# Altium schematic sheet 05 - BANK 14 DUAL ETHERNET, UART, GPIO.SchDoc
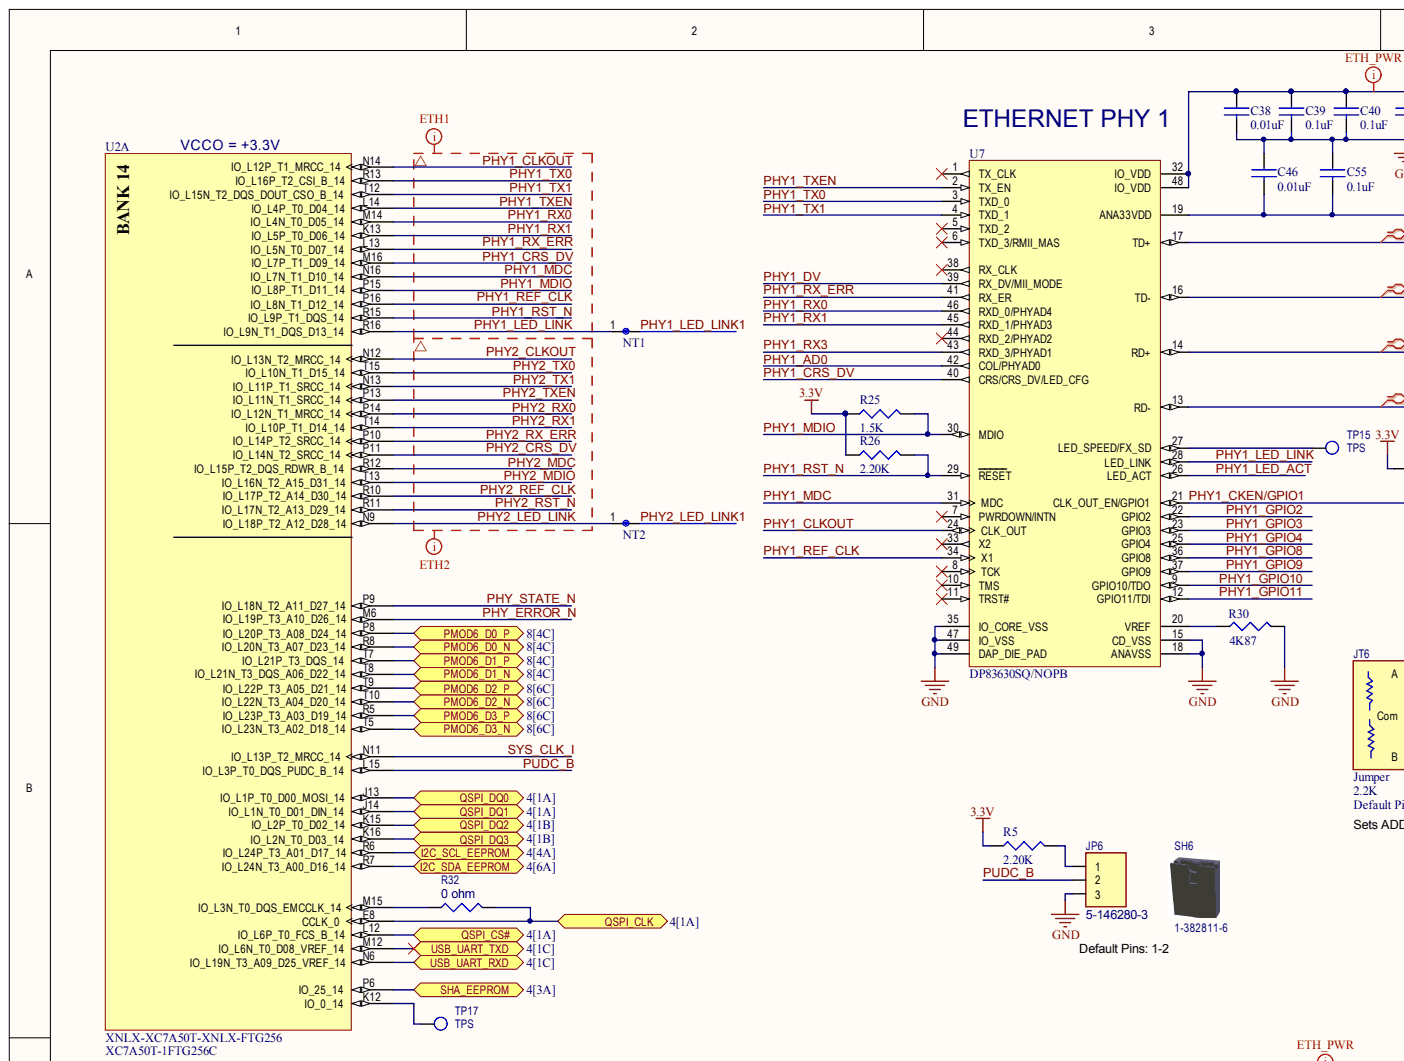
[diagram p0: 05 - BANK 14 DUAL ETHERNET, UART, GPIO.SchDoc, top left]
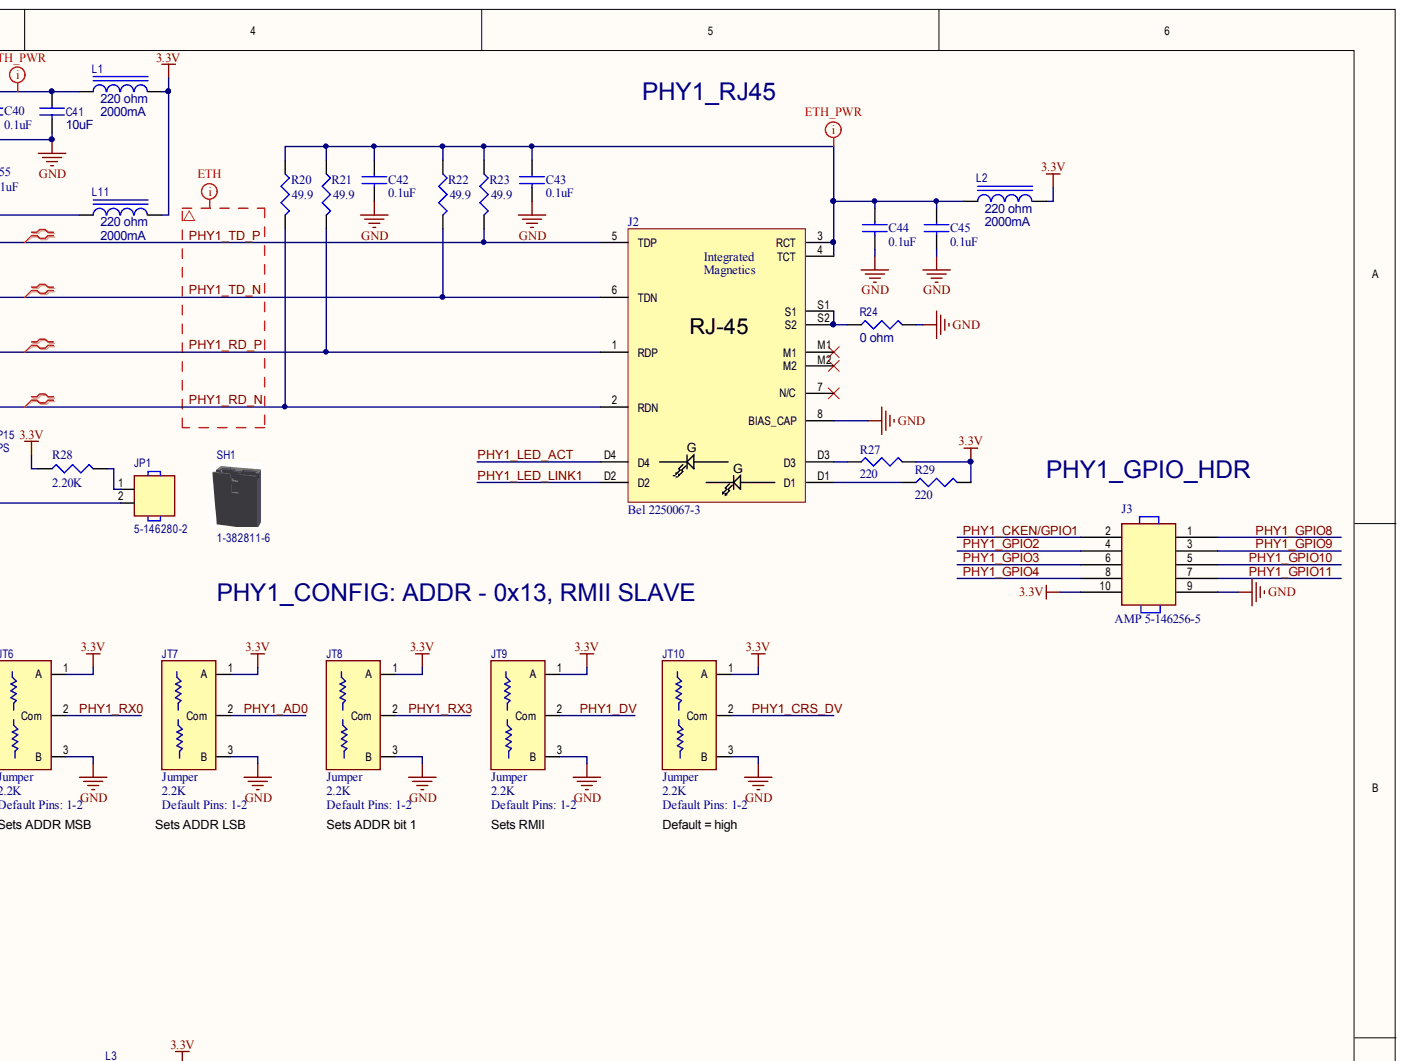
[diagram p1: 05 - BANK 14 DUAL ETHERNET, UART, GPIO.SchDoc, top right]
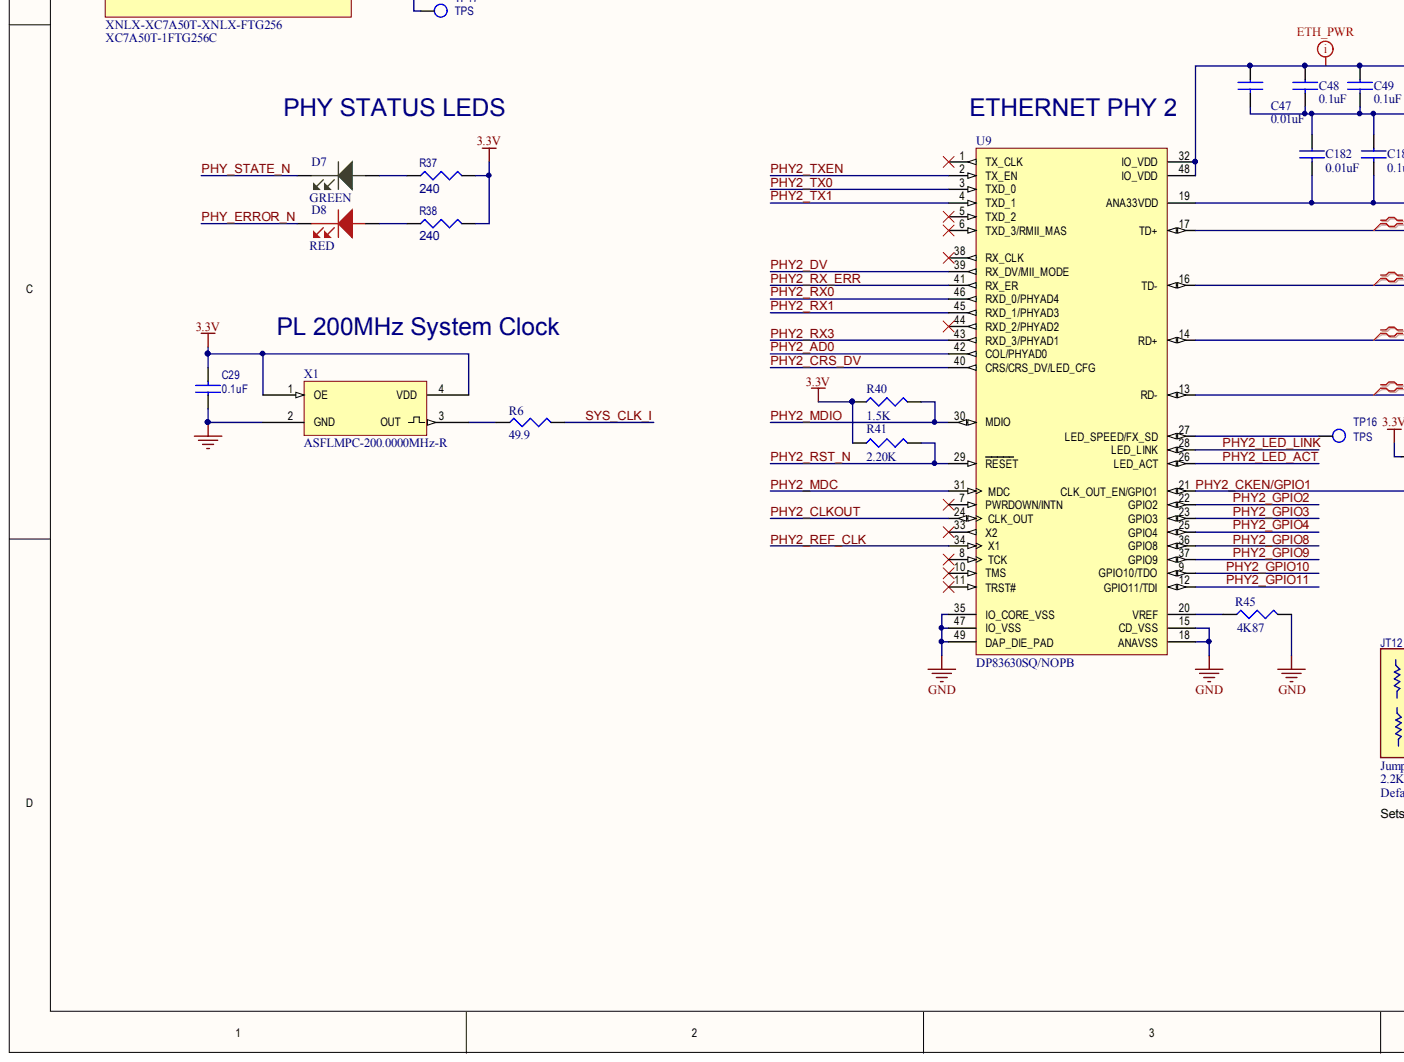
[diagram p2: 05 - BANK 14 DUAL ETHERNET, UART, GPIO.SchDoc, bottom left]
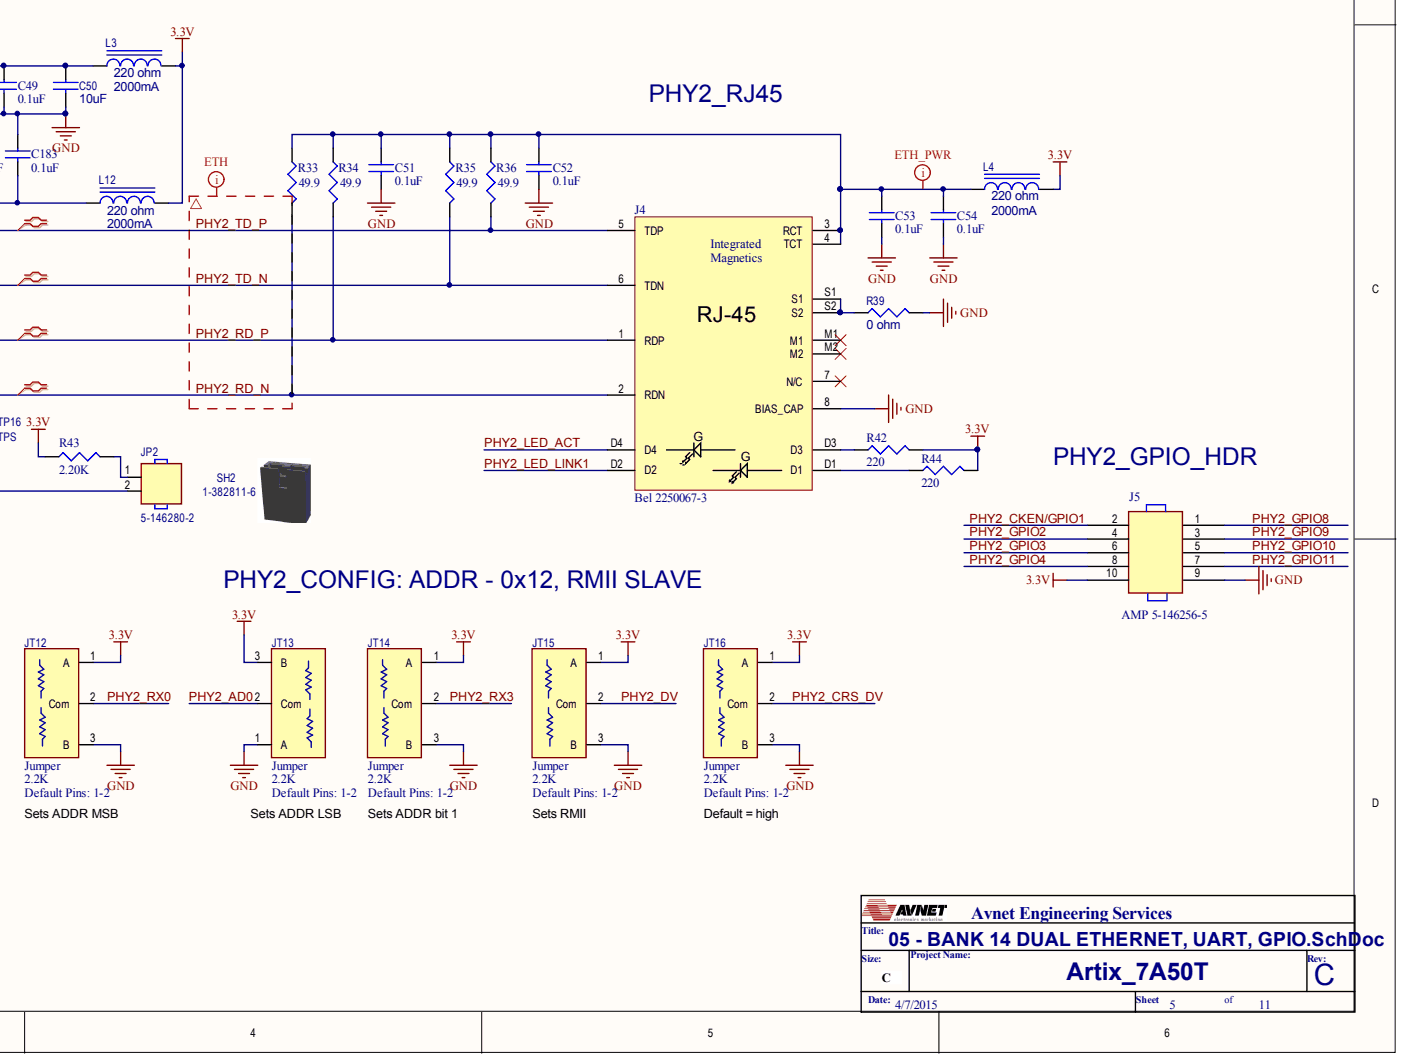
[diagram p3: 05 - BANK 14 DUAL ETHERNET, UART, GPIO.SchDoc, bottom right]

## Parts
C29  value 0.1uF  [C0201]  (hidden comment: 6.3V)
C38  value 0.01uF  [C0201]  (hidden comment: PC003)
C39  value 0.1uF  [C0201]  (hidden comment: PC522)
C40  value 0.1uF  CLASSNAME: ETH_PWR  [C0201]  (hidden comment: PC522)
C41  10uF  CLASSNAME: ETH_PWR  [C0603]
C42  value 0.1uF  [C0201]  (hidden comment: PC522)
C43  value 0.1uF  [C0201]  (hidden comment: PC522)
C44  value 0.1uF  [C0201]  (hidden comment: PC522)
C45  value 0.1uF  [C0201]  (hidden comment: PC522)
C46  value 0.01uF  [C0201]  (hidden comment: PC003)
C47  value 0.01uF  [C0201]  (hidden comment: PC003)
C48  value 0.1uF  CLASSNAME: ETH_PWR  [C0201]  (hidden comment: PC522)
C49  value 0.1uF  CLASSNAME: ETH_PWR  [C0201]  (hidden comment: PC522)
C50  10uF  [C0603]
C51  value 0.1uF  [C0201]  (hidden comment: PC522)
C52  value 0.1uF  [C0201]  (hidden comment: PC522)
C53  value 0.1uF  CLASSNAME: ETH_PWR  [C0201]  (hidden comment: PC522)
C54  value 0.1uF  CLASSNAME: ETH_PWR  [C0201]  (hidden comment: PC522)
C55  value 0.1uF  [C0201]  (hidden comment: PC522)
C182  value 0.01uF  [C0201]  (hidden comment: PC003)
C183  value 0.1uF  [C0201]  (hidden comment: PC522)
D7  Device: GREEN  [LED_LITE-LTST-C191TBKT-G]  (hidden comment: DL002)
D8  Device: RED  [LED_LITE-LTST-C191KRKT]  (hidden comment: DL015)
J2  MFGPN: Bel 2250067-3  [TRP_5-6605758-7]  (hidden comment: Bel 2250067-3  RJ45 4 Pin TH)
J3  MFGPN: AMP 5-146256-5  [HDR5X2]  (hidden comment: HEADER 5X2)
J4  MFGPN: Bel 2250067-3  [TRP_5-6605758-7]  (hidden comment: Bel 2250067-3  RJ45 4 Pin TH)
J5  MFGPN: AMP 5-146256-5  [HDR5X2]  (hidden comment: HEADER 5X2)
JP1  5-146280-2  [HDR2-100]
JP2  5-146280-2  [HDR2-100]
JP6  Device: 5-146280-3  [HDR3-100]  (hidden comment: EH003)
JT6  value 2.2K  Default Pins: 1-2  Device: Jumper  [R0402-DUAL_JMP]
JT7  value 2.2K  Default Pins: 1-2  Device: Jumper  [R0402-DUAL_JMP]
JT8  value 2.2K  Default Pins: 1-2  Device: Jumper  [R0402-DUAL_JMP]
JT9  value 2.2K  Default Pins: 1-2  Device: Jumper  [R0402-DUAL_JMP]
JT10  value 2.2K  Default Pins: 1-2  Device: Jumper  [R0402-DUAL_JMP]
JT12  value 2.2K  Default Pins: 1-2  Device: Jumper  [R0402-DUAL_JMP]
JT13  value 2.2K  Default Pins: 1-2  Device: Jumper  [R0402-DUAL_JMP]
JT14  value 2.2K  Default Pins: 1-2  Device: Jumper  [R0402-DUAL_JMP]
JT15  value 2.2K  Default Pins: 1-2  Device: Jumper  [R0402-DUAL_JMP]
JT16  value 2.2K  Default Pins: 1-2  Device: Jumper  [R0402-DUAL_JMP]
L1  value 220 ohm  Imax: 2000mA  [L0805]  (hidden comment: PF009)
L2  value 220 ohm  Imax: 2000mA  [L0805]  (hidden comment: PF009)
L3  value 220 ohm  Imax: 2000mA  [L0805]  (hidden comment: PF009)
L4  value 220 ohm  CLASSNAME: ETH_PWR  Imax: 2000mA  [L0805]  (hidden comment: PF009)
L11  value 220 ohm  Imax: 2000mA  [L0805]  (hidden comment: PF009)
L12  value 220 ohm  Imax: 2000mA  [L0805]  (hidden comment: PF009)
NT1  PO030  [NT2X4-2210]  (value not drawn)
NT2  PO030  [NT2X4-2210]  (value not drawn)
R5  value 2.20K  [R0402]  (hidden comment: 2K20 0402)
R6  value 49.9  [R0402]  (hidden comment: 49R9 0402)
R20  value 49.9  [R0402]  (hidden comment: 49R9 0402)
R21  value 49.9  [R0402]  (hidden comment: 49R9 0402)
R22  value 49.9  [R0402]  (hidden comment: 49R9 0402)
R23  value 49.9  [R0402]  (hidden comment: 49R9 0402)
R24  0 ohm  [R0402]
R25  value 1.5K  [R0402]  (hidden comment: 1K50 0402)
R26  value 2.20K  [R0402]  (hidden comment: 2K20 0402)
R27  value 220  [R0402]  (hidden comment: 220R 0402)
R28  value 2.20K  [R0402]  (hidden comment: 2K20 0402)
R29  value 220  [R0402]  (hidden comment: 220R 0402)
R30  value 4K87  [R0402]  (hidden comment: RES 4K87 0402 1%)
R32  0 ohm  [R0402]
R33  value 49.9  [R0402]  (hidden comment: 49R9 0402)
R34  value 49.9  [R0402]  (hidden comment: 49R9 0402)
R35  value 49.9  [R0402]  (hidden comment: 49R9 0402)
R36  value 49.9  [R0402]  (hidden comment: 49R9 0402)
R37  240  [R0402]
R38  240  [R0402]
R39  0 ohm  [R0402]
R40  value 1.5K  [R0402]  (hidden comment: 1K50 0402)
R41  value 2.20K  [R0402]  (hidden comment: 2K20 0402)
R42  value 220  [R0402]  (hidden comment: 220R 0402)
R43  value 2.20K  [R0402]  (hidden comment: 2K20 0402)
R44  value 220  [R0402]  (hidden comment: 220R 0402)
R45  value 4K87  [R0402]  (hidden comment: RES 4K87 0402 1%)
SH1  1-382811-6  (mechanical)
SH2  1-382811-6  (mechanical)
SH6  1-382811-6  (mechanical)
TP15  TPS  [TP24TH]
TP16  TPS  [TP24TH]
TP17  TPS  [TP24TH]
U2  XNLX-XC7A50T-XNLX-FTG256  MFGPN: XC7A50T-1FTG256C  [FTG256]  (part A)
U7  DP83630  MFGPN: DP83630SQ/NOPB  [LFCSP48]
U9  DP83630  MFGPN: DP83630SQ/NOPB  [LFCSP48]
X1  200MHz Fixed freq 3.3V  [XTAL_ASFLMPC]  (value not drawn)

## Ports
I2C_SCL_EEPROM, I2C_SDA_EEPROM, PMOD6_D0_N, PMOD6_D0_P, PMOD6_D1_N, PMOD6_D1_P, PMOD6_D2_N, PMOD6_D2_P, PMOD6_D3_N, PMOD6_D3_P, QSPI_CLK, QSPI_CS#, QSPI_DQ0, QSPI_DQ1, QSPI_DQ2, QSPI_DQ3, SHA_EEPROM, USB_UART_RXD, USB_UART_TXD

## Nets
3.3V: C29.2 J3.10 J5.10 JT6.1 JT7.1 JT8.1 JT9.1 JT10.1 JT12.1 JT13.3 JT14.1 JT15.1 JT16.1 L1.2 L2.2 L3.2 L4.2 L11.2 L12.2 R5.1 R25.1 R26.1 R27.2 R28.1 R29.2 R37.2 R38.2 R40.1 R41.1 R42.2 R43.1 R44.2 X1.1(OE) X1.4(VDD)
GND: C29.1 C38.2 C39.2 C40.2 C41.2 C42.2 C43.2 C44.2 C45.2 C46.1 C47.2 C48.2 C49.2 C50.2 C51.2 C52.2 C53.2 C54.2 C55.1 C182.1 C183.1 J2.8(BIAS_CAP) J3.9 J4.8(BIAS_CAP) J5.9 JP6.3 JT6.3 JT7.3 JT8.3 JT9.3 JT10.3 JT12.3 JT13.1 JT14.3 JT15.3 JT16.3 R24.1 R30.2 R39.1 R45.2 U7.15(CD_VSS) U7.18(ANAVSS) U7.35(IO_CORE_VSS) U7.47(IO_VSS) U7.49(DAP_DIE_PAD) U9.15(CD_VSS) U9.18(ANAVSS) U9.35(IO_CORE_VSS) U9.47(IO_VSS) U9.49(DAP_DIE_PAD) X1.2(GND)
I2C_SCL_EEPROM: U2.R6(IO_L24P_T3_A01_D17_14) ; port I2C_SCL_EEPROM
I2C_SDA_EEPROM: U2.R7(IO_L24N_T3_A00_D16_14) ; port I2C_SDA_EEPROM
PHY1_AD0: JT7.2 U7.42(COL/PHYAD0)
PHY1_CKEN/GPIO1: J3.2 JP1.2 U7.21(CLK_OUT_EN/GPIO1)
PHY1_CLKOUT: U2.N14(IO_L12P_T1_MRCC_14) U7.24(CLK_OUT)
PHY1_CRS_DV: JT10.2 U2.M16(IO_L7P_T1_D09_14) U7.40(CRS/CRS_DV/LED_CFG)
PHY1_DV: JT9.2 U7.39(RX_DV/MII_MODE)
PHY1_GPIO2: J3.4 U7.22(GPIO2)
PHY1_GPIO3: J3.6 U7.23(GPIO3)
PHY1_GPIO4: J3.8 U7.25(GPIO4)
PHY1_GPIO8: J3.1 U7.36(GPIO8)
PHY1_GPIO9: J3.3 U7.37(GPIO9)
PHY1_GPIO10: J3.5 U7.9(GPIO10/TDO)
PHY1_GPIO11: J3.7 U7.12(GPIO11/TDI)
PHY1_LED_ACT: J2.D4 U7.26(LED_ACT)
PHY1_LED_LINK: NT1.1 U2.R16(IO_L9N_T1_DQS_D13_14) U7.28(LED_LINK)
PHY1_LED_LINK1: J2.D2 NT1.2
PHY1_MDC: U2.N16(IO_L7N_T1_D10_14) U7.31(MDC)
PHY1_MDIO: R25.2 U2.P15(IO_L8P_T1_D11_14) U7.30(MDIO)
PHY1_RD_N: J2.2(RDN) R20.2 U7.13(RD-)
PHY1_RD_P: J2.1(RDP) R21.2 U7.14(RD+)
PHY1_REF_CLK: U2.P16(IO_L8N_T1_D12_14) U7.34(X1)
PHY1_RST_N: R26.2 U2.R15(IO_L9P_T1_DQS_14) U7.29(RESET)
PHY1_RX0: JT6.2 U2.M14(IO_L4N_T0_D05_14) U7.46(RXD_0/PHYAD4)
PHY1_RX1: U2.K13(IO_L5P_T0_D06_14) U7.45(RXD_1/PHYAD3)
PHY1_RX3: JT8.2 U7.43(RXD_3/PHYAD1)
PHY1_RX_ERR: U2.L13(IO_L5N_T0_D07_14) U7.41(RX_ER)
PHY1_TD_N: J2.6(TDN) R22.2 U7.16(TD-)
PHY1_TD_P: J2.5(TDP) R23.2 U7.17(TD+)
PHY1_TX0: U2.R13(IO_L16P_T2_CSI_B_14) U7.3(TXD_0)
PHY1_TX1: U2.T12(IO_L15N_T2_DQS_DOUT_CSO_B_14) U7.4(TXD_1)
PHY1_TXEN: U2.L14(IO_L4P_T0_D04_14) U7.2(TX_EN)
PHY2_AD0: JT13.2 U9.42(COL/PHYAD0)
PHY2_CKEN/GPIO1: J5.2 JP2.2 U9.21(CLK_OUT_EN/GPIO1)
PHY2_CLKOUT: U2.N12(IO_L13N_T2_MRCC_14) U9.24(CLK_OUT)
PHY2_CRS_DV: JT16.2 U2.P11(IO_L14N_T2_SRCC_14) U9.40(CRS/CRS_DV/LED_CFG)
PHY2_DV: JT15.2 U9.39(RX_DV/MII_MODE)
PHY2_GPIO2: J5.4 U9.22(GPIO2)
PHY2_GPIO3: J5.6 U9.23(GPIO3)
PHY2_GPIO4: J5.8 U9.25(GPIO4)
PHY2_GPIO8: J5.1 U9.36(GPIO8)
PHY2_GPIO9: J5.3 U9.37(GPIO9)
PHY2_GPIO10: J5.5 U9.9(GPIO10/TDO)
PHY2_GPIO11: J5.7 U9.12(GPIO11/TDI)
PHY2_LED_ACT: J4.D4 U9.26(LED_ACT)
PHY2_LED_LINK: NT2.1 U2.N9(IO_L18P_T2_A12_D28_14) U9.28(LED_LINK)
PHY2_LED_LINK1: J4.D2 NT2.2
PHY2_MDC: U2.R12(IO_L15P_T2_DQS_RDWR_B_14) U9.31(MDC)
PHY2_MDIO: R40.2 U2.T13(IO_L16N_T2_A15_D31_14) U9.30(MDIO)
PHY2_RD_N: J4.2(RDN) R33.2 U9.13(RD-)
PHY2_RD_P: J4.1(RDP) R34.2 U9.14(RD+)
PHY2_REF_CLK: U2.R10(IO_L17P_T2_A14_D30_14) U9.34(X1)
PHY2_RST_N: R41.2 U2.R11(IO_L17N_T2_A13_D29_14) U9.29(RESET)
PHY2_RX0: JT12.2 U2.P14(IO_L12N_T1_MRCC_14) U9.46(RXD_0/PHYAD4)
PHY2_RX1: U2.T14(IO_L10P_T1_D14_14) U9.45(RXD_1/PHYAD3)
PHY2_RX3: JT14.2 U9.43(RXD_3/PHYAD1)
PHY2_RX_ERR: U2.P10(IO_L14P_T2_SRCC_14) U9.41(RX_ER)
PHY2_TD_N: J4.6(TDN) R35.2 U9.16(TD-)
PHY2_TD_P: J4.5(TDP) R36.2 U9.17(TD+)
PHY2_TX0: U2.T15(IO_L10N_T1_D15_14) U9.3(TXD_0)
PHY2_TX1: U2.N13(IO_L11P_T1_SRCC_14) U9.4(TXD_1)
PHY2_TXEN: U2.P13(IO_L11N_T1_SRCC_14) U9.2(TX_EN)
PHY_ERROR_N: D8.C U2.M6(IO_L19P_T3_A10_D26_14)
PHY_STATE_N: D7.C U2.P9(IO_L18N_T2_A11_D27_14)
PMOD6_D0_N: U2.R8(IO_L20N_T3_A07_D23_14) ; port PMOD6_D0_N
PMOD6_D0_P: U2.P8(IO_L20P_T3_A08_D24_14) ; port PMOD6_D0_P
PMOD6_D1_N: U2.T8(IO_L21N_T3_DQS_A06_D22_14) ; port PMOD6_D1_N
PMOD6_D1_P: U2.T7(IO_L21P_T3_DQS_14) ; port PMOD6_D1_P
PMOD6_D2_N: U2.T10(IO_L22N_T3_A04_D20_14) ; port PMOD6_D2_N
PMOD6_D2_P: U2.T9(IO_L22P_T3_A05_D21_14) ; port PMOD6_D2_P
PMOD6_D3_N: U2.T5(IO_L23N_T3_A02_D18_14) ; port PMOD6_D3_N
PMOD6_D3_P: U2.R5(IO_L23P_T3_A03_D19_14) ; port PMOD6_D3_P
PUDC_B: JP6.2 U2.L15(IO_L3P_T0_DQS_PUDC_B_14)
QSPI_CLK: R32.2 U2.E8(CCLK_0) ; port QSPI_CLK
QSPI_CS#: U2.L12(IO_L6P_T0_FCS_B_14) ; port QSPI_CS#
QSPI_DQ0: U2.J13(IO_L1P_T0_D00_MOSI_14) ; port QSPI_DQ0
QSPI_DQ1: U2.J14(IO_L1N_T0_D01_DIN_14) ; port QSPI_DQ1
QSPI_DQ2: U2.K15(IO_L2P_T0_D02_14) ; port QSPI_DQ2
QSPI_DQ3: U2.K16(IO_L2N_T0_D03_14) ; port QSPI_DQ3
SHA_EEPROM: U2.P6(IO_25_14) ; port SHA_EEPROM
SYS_CLK_I: R6.2 U2.N11(IO_L13P_T2_MRCC_14)
USB_UART_RXD: U2.N6(IO_L19N_T3_A09_D25_VREF_14) ; port USB_UART_RXD
USB_UART_TXD: U2.M12(IO_L6N_T0_D08_VREF_14) ; port USB_UART_TXD
NetC38_1: C38.1 C39.1 C40.1 C41.1 L1.1 U7.32(IO_VDD) U7.48(IO_VDD)
NetC42_1: C42.1 C43.1 C44.1 C45.1 J2.3(RCT) J2.4(TCT) L2.1 R20.1 R21.1 R22.1 R23.1
NetC46_2: C46.2 C55.2 L11.1 U7.19(ANA33VDD)
NetC47_1: C47.1 C48.1 C49.1 C50.1 L3.1 U9.32(IO_VDD) U9.48(IO_VDD)
NetC51_1: C51.1 C52.1 C53.1 C54.1 J4.3(RCT) J4.4(TCT) L4.1 R33.1 R34.1 R35.1 R36.1
NetC182_2: C182.2 C183.2 L12.1 U9.19(ANA33VDD)
NetD7_A: D7.A R37.1
NetD8_A: D8.A R38.1
NetJ2_D1: J2.D1 R29.1
NetJ2_D3: J2.D3 R27.1
NetJ2_S1: J2.S1 J2.S2 R24.2
NetJ4_D1: J4.D1 R44.1
NetJ4_D3: J4.D3 R42.1
NetJ4_S1: J4.S1 J4.S2 R39.2
NetJP1_1: JP1.1 R28.2
NetJP2_1: JP2.1 R43.2
NetJP6_1: JP6.1 R5.2
NetR6_1: R6.1 X1.3(OUT)
NetR30_1: R30.1 U7.20(VREF)
NetR32_1: R32.1 U2.M15(IO_L3N_T0_DQS_EMCCLK_14)
NetR45_1: R45.1 U9.20(VREF)
NetTP15_1: TP15.1 U7.27(LED_SPEED/FX_SD)
NetTP16_1: TP16.1 U9.27(LED_SPEED/FX_SD)
NetTP17_1: TP17.1 U2.K12(IO_0_14)
N00164:
N00165:
N00166:
N00167:

Not connected: J2 7(N/C) M1 M2; J4 7(N/C) M1 M2; U7 1(TX_CLK) 5(TXD_2) 6(TXD_3/RMII_MAS) 7(PWRDOWN/INTN) 8(TCK) 10(TMS) 11(TRST#) 33(X2) 38(RX_CLK) 44(RXD_2/PHYAD2); U9 1(TX_CLK) 5(TXD_2) 6(TXD_3/RMII_MAS) 7(PWRDOWN/INTN) 8(TCK) 10(TMS) 11(TRST#) 33(X2) 38(RX_CLK) 44(RXD_2/PHYAD2)

## Library data of the parts
C29 = AVPC-0000522: Cap Ceramic 0.1uF 6.3V X5R 10% SMD 0201 85°C Paper T/R; Database Table Name: Passive-Cap$; MFG Code: MUR; MFGPN: GRM033R60J104KE19D; Note: Cap Ceramic 0.1uF 6.3V X5R 10% SMD 00201 85°C Paper T/R; Component Kind: Standard; Library Name: Avnet_DBlib.DbLib
C38 C46 C47 C182 = AVPC-0000003: CAP SM 0.01uF 10V 10% X5R C0201; PartCode: PC; Database Table Name: Passive-Cap$; MFG Code: AVX; MFGPN: 0201ZD103KAT2A; AsyTxt: PC003; AVLNo: AVPC-0000003; CLASSNAME: ETH_PWR; Component Kind: Standard; ComponentLink1Description: Datasheet; ComponentLink2Description: MFR Website; ComponentLink2URL: www.avx.com; Device: CAP; Dielectric: X5R; ESR: CAP; PkgType: SM; RoHS: Yes; Status: Preliminary; Tol: 10%; Volt: 10V; ComponentLink1URL: C:\AVDBLIB\DATASHEETS\AVX_CX5R.pdf; Library Name: Avnet_DBlib.DbLib
C39 C40 C42-C45 C48 C49 C51-C55 C183 = AVPC-0000522: CAP SM 0.1uF 10V 10% X5R C0201; PartCode: PC; Database Table Name: Passive-Cap$; MFG Code: AVX; MFGPN: 02016D104KAT2A; AsyTxt: PC522; AVLNo: AVPC-0000522; Component Kind: Standard; ComponentLink1Description: Datasheet; Device: CAP; Dielectric: X5R; PkgType: SM; RoHS: Yes; Status: Preliminary; Tol: 10%; Volt: 10V; ComponentLink1URL: C:\AVDBLIB\DATASHEETS\AVX_CCOG.pdf; Library Name: Avnet_DBlib.DbLib
  C39 C42-C45 C51 C52 C55 C183: CLASSNAME: ETH_PWR
C41 C50 = AVPC-0000086: CAP SM 10uF 6.3V 20% X5R C0603; PartCode: PC; AsyTxt: PC086; Database Table Name: Passive-Cap$; MFG Code: AVX; MFGPN: 06036D106MAT2A; AVLNo: AVPC-0000086; Component Kind: Standard; ComponentLink1Description: Datasheet; Device: CAP; Dielectric: X5R; ESR: CAP; PkgType: SM; RoHS: Yes; Status: Preliminary; Tol: 20%; Value: 10uF; Volt: 6.3V; ComponentLink1URL: C:\AVDBLIB\DATASHEETS\AVX_CCOG.pdf; Library Name: Avnet_DBlib.DbLib
  C50: CLASSNAME: ETH_PWR
D7 = AVDL-0000002: LED, Grn, 565nm, Vf=2.1V, If=20mA, lv=12mcd; PartCode: DL; Database Table Name: Discrete-LED$; If: 20mA; LV: 12mcd; MFG Code: LTP; MFGPN: LTST-C190GKT; PkgType: SM; Vf: 2.1V; AsyTxt: DL002; AVLNo: AVDL-0000002; Component Kind: Standard; ComponentLink1Description: Datasheet; Status: Preliminary; WaveLength: 565nM; ComponentLink1URL: C:\AVDBLIB\DATASHEETS\ltst-c190gkt.pdf; Library Name: Avnet_DBlib.DbLib
D8 = AVDL-0000015: LED, Red, 631nm, Vf=2.0V, If=20mA, lv=54mcd; PartCode: DL; Database Table Name: Discrete-LED$; If: 20mA; LV: 54mcd; MFG Code: LTP; MFGPN: LTST-C191KRKT; PkgType: SM; Vf: 2.0V; AsyTxt: DL015; AVLNo: AVDL-0000015; Component Kind: Standard; ComponentLink1Description: Datasheet; Status: Preliminary; WaveLength: 631nM; ComponentLink1URL: C:\AVDBLIB\DATASHEETS\LTST-C191KRKT.pdf; Library Name: Avnet_DBlib.DbLib
J2 J4 = TRP 2250067-3 RJ45 4 Pin TH: Bel RJ45, Integrated Mags, 10/100T, TH; Component Kind: Standard; Library Name: Artix_7A50.SCHLIB; PKG: TH
J3 J5 = HEADER 5X2: 5x2 0.1" Through-hole Header; AES Part #: AVEH-0000009; Component Kind: Standard; Library Name: Connector.SchLib; Preferred Part #: AMP 5-146256-5; Status: Preliminary
JP1 JP2 = HDR2: CONN HEADR BRKWAY .100 2POS STR; MFG Code: AMP; Component Kind: Standard; MFGPN: 5-146280-2; Note: CONN HEADR BRKWAY .100 2POS STR; Library Name: ZYNQ_Z7045_MITX_REVA.SCHLIB
JP6 = AVEH-0000003: Header TH 100mil 3x1  HDR3 HDR3-100; PartCode: EH; Database Table Name: EM-Header$; MFG Code: AMP; AsyTxt: EH003; AVLNo: AVEH-0000003; Col-Row: 3x1; Component Kind: Standard; ComponentLink1Description: Datasheet; Device Type: Header; MFGPN: 5-146280-3; Pitch: 100mil; PkgType: TH; RoHS: Yes; Status: Preliminary; ComponentLink1URL: C:\AVDBLIB\DATASHEETS\146280.pdf; Library Name: Avnet_DBlib.DbLib
JT6-JT10 JT12-JT16 = AVPO-0000025: Jumper 3-pad 0402 RES-3padJPR R0402-DUAL_JMP - 2K Ohm at Pads 1-2; PartCode: PO; Database Table Name: Passive-Other$; Device Type: 3-pad 0R0 0402; MFG Code: DAL; MFGPN: CRCW04022K20FKED: Placed at pads 1-2; PkgType: SM; Component Kind: Standard; ComponentLink1Description: Datasheet; RoHS: Yes; Status: Preliminary; ComponentLink1URL: C:\AVDBLIB\DATASHEETS\Dale_CRCW.pd; Library Name: Avnet_DBlib.DbLib
L1-L4 L11 L12 = AVPF-0000009: FB SM 220 ohm@100MHz 2000mA 0.25 0.045 ohm@DC L0805; PartCode: PF; Database Table Name: Passive-Ferrite Bead$; MFG Code: MUR; MFGPN: BLM21PG221SN1D; Test Freq: 100MHz; Tol: 0.25; AsyTxt: PF009; AVLNo: AVPF-0000009; Component Kind: Standard; ComponentLink1Description: Datasheet; DCR: 0.045 ohm; Device: FB; PkgType: SM; RoHS: Yes; Status: Preliminary; ComponentLink1URL: C:\AVDBLIB\DATASHEETS\L0110S0100BLM21P.pdf; Library Name: Avnet_DBlib.DbLib
  L1 L2 L11 L12: CLASSNAME: ETH_PWR
NT1 NT2 = AVPO-0000030: Net Tie 2 points; PartCode: PO; Database Table Name: Passive-Other$; MFG Code: None; PkgType: SM; AsyTxt: PO030; AVLNo: AVPO-0000030; Component Kind: Standard; ComponentLink1Description: Datasheet; MFGPN: None; RoHS: Yes; Status: Preliminary; ComponentLink1URL: C:\AVDBLIB\DATASHEETS\; Library Name: Avnet_DBlib.DbLib
R5 R26 R28 R41 R43 = RESC 2K20 0402 5%: Chip Resistor 0402 2.20K 1/16W 5%; AES Part #: AVPR-0000075; Preferred Part #: DAL CRCW04022K20JNED; MFGPN: CRCW04022K20JNED; Component Kind: Standard; Library Name: Passive.SchLib; Signal Integrity: RES; Simulation: RES; Status: Preliminary
R6 R20-R23 R33-R36 = RESC 49R9 0402: Chip Resistor 0402 49R9 1/16W 1%; AES Part #: AVPR-0000090; Preferred Part #: DAL CRCW040249R9FKED; MFGPN: CRCW040249R9FKED; Component Kind: Standard; Library Name: Passive.SchLib; Signal Integrity: RES; Simulation: RES; Status: Preliminary
  R33-R35: CLASSNAME: ETH_PWR
R24 R32 R39 = AVPR-0000027: RES SM 0 ohm 62mW J R0402; PartCode: PR; AsyTxt: PR027; Database Table Name: Passive-Res$; MFG Code: DAL; MFGPN: CRCW04020000Z0ED; AVLNo: AVPR-0000027; Component Kind: Standard; ComponentLink1Description: Datasheet; Device: RES; PkgType: SM; RoHS: Yes; Status: Preliminary; Value: 0 ohm; Watt: 62mW; ComponentLink1URL: C:\AVDBLIB\DATASHEETS\Dale_CRCW.pd; Library Name: Avnet_DBlib.DbLib
R25 R40 = RESC 1K50 0402: Chip Resistor 0402 1.50K 1/16W 1%; AES Part #: AVPR-0000056; Preferred Part #: DAL CRCW04021K50FKED; MFGPN: CRCW04021K50FKED; Component Kind: Standard; Library Name: Passive.SchLib; Signal Integrity: RES; Simulation: RES; Status: Preliminary
R27 R29 R42 R44 = RESC 220R 0402: Chip Resistor 0402 220R 1/16W 5%; AES Part #: AVPR-0000063; Preferred Part #: DAL CRCW0402220RJNED; MFGPN: CRCW0402220RJNED; Component Kind: Standard; Library Name: Passive.SchLib; Signal Integrity: RES; Simulation: RES; Status: Preliminary
R30 R45 = RES 4K87 0402 1%: MFG: VSH; MFGPN: CRCW04024K87FKED; PKG: 0402; Component Kind: Standard; Description: RES 4K87 0402 1%; Library Name: Artix_7A50.SchLib
R37 R38 = AVPR-0000511: RES SM 240 62mW 0.01 R0402; Alt1MFR: NIC; PartCode: PR; Alt1PN: NRC04F2400TRF; AsyTxt: PR511; Database Table Name: Passive-Res$; MFG Code: DAL; MFGPN: CRCW0402240RFKED; Power: 0; Tol: 0.01; Availability: 1M-AV; AVLNo: AVPR-0000511; Component Kind: Standard; ComponentLink1Description: Datasheet; Cost: .01; Device: RES; PkgType: SM; Received: 0; RoHS: Yes; Size: 1.9; Status: Preliminary; Value: 240; Watt: 62mW; ComponentLink1URL: C:\AVDBLIB\DATASHEETS\Dale_CRCW.pd; Library Name: Avnet_DBlib.DbLib
SH1 SH2 SH6 = AVEH-0000115: SHUNT,ECON,PHBR 15AU,BLACK L/P; MFG Code: AMP; MFGPN: 1-382811-6; Note: SHUNT,ECON,PHBR 15AU,BLACK L/P; Library Name: ZYNQ_Z7045_MITX_REVA.SCHLIB
TP15-TP17 = AVPO-0000039: Test Point 24 mil pad, round, 10 mil hole, small symbol TPS TP24TH; MFG Code: None; Component Kind: Standard; DNP: DNP; MFGPN: None; Library Name: ZYNQ_Z7045_MITX_REVA.SCHLIB
U2 = XNLX-XC7A50T-XNLX-FTG256: PKG: FBGA256; Component Kind: Standard; Library Name: Artix_7A50_1.SCHLIB
U7 U9 = IN-LNTX-DP83630-49: DP83630 Precision PHYTER™ - IEEE 1588 Precision Time Protocol Transceiver; MFG: TI; Component Kind: Standard; Library Name: IN-LNTX-DP83630-49.SchLib
X1 = ASFLMPC-200.0000MHz-R: 200MHz MEMs, 3.3V, LVCMOS, 3.2x5mm; MFG: ABR; Component Kind: Standard; Library Name: Artix_7A50.SchLib; MFGPN: ASFLMPC-200.0000MHz-R; PKG: 3.2x5mm
